# Altium schematic sheet MAIN.SchDoc
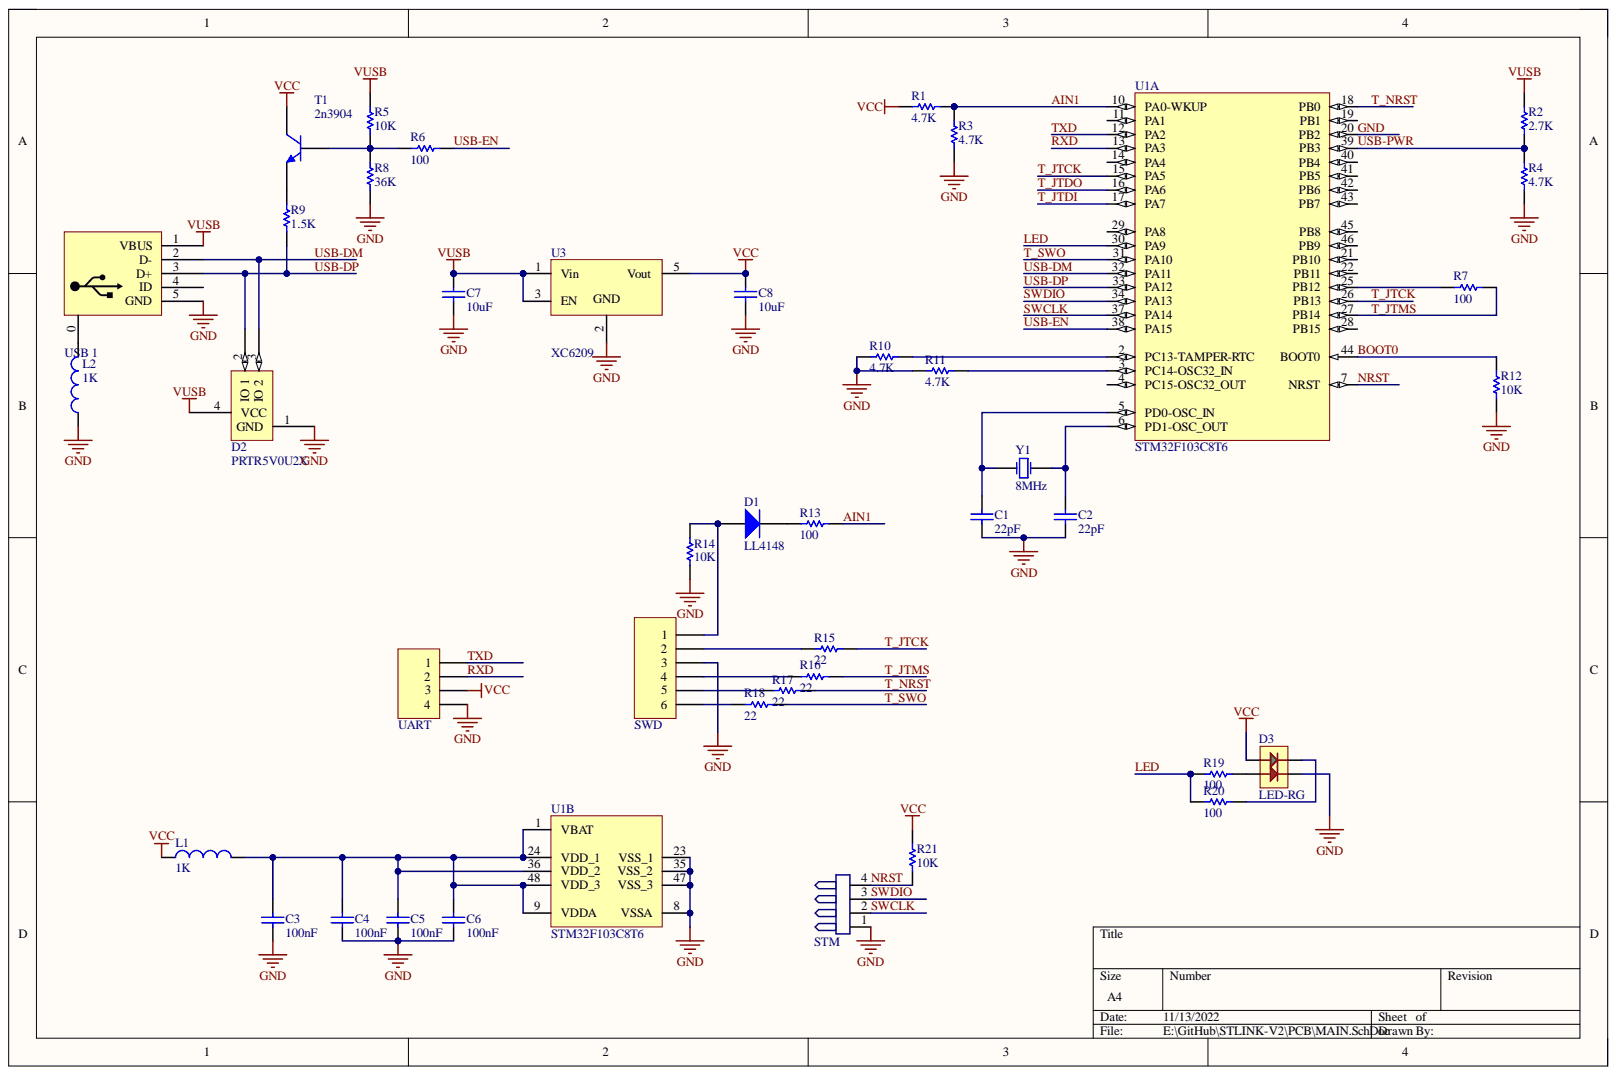
[diagram p0: MAIN.SchDoc]

## Parts
C1  22pF  [C603]
C2  22pF  [C603]
C3  100nF  [C603]
C4  100nF  [C603]
C5  100nF  [C603]
C6  100nF  [C603]
C7  10uF  [C805]
C8  10uF  [C805]
D1  LL4148  [SOD-80]
D2  PRTR5V0U2X  [SOT-143B]
D3  LED-RG  [LED-1210]
L1  1K  [r805]
L2  1K  [r805]
R1  4.7K  [R0603]
R2  2.7K  [R0603]
R3  4.7K  [R0603]
R4  4.7K  [R0603]
R5  10K  [R0603]
R6  100  [R0603]
R7  100  [R0603]
R8  36K  [R0603]
R9  1.5K  [R0603]
R10  4.7K  [R0603]
R11  4.7K  [R0603]
R12  10K  [R0603]
R13  100  [R0603]
R14  10K  [R0603]
R15  22  [R0603]
R16  22  [R0603]
R17  22  [R0603]
R18  22  [R0603]
R19  100  [R0603]
R20  100  [R0603]
R21  10K  [R0603]
STM  PHDR 4  [PH-4]  (value not drawn)
SWD  CONN-6  [XH-6]  (value not drawn)
T1  2n3904  [SOT-23-3]
U1  STM32F103C8T6  [LQFP-48]  (parts A,B)
U3  XC6209  [SOT-23-5]
UART  CONN-4  [XH-4]  (value not drawn)
USB_1  USB MINI  [USB-MINI-SMD]  (value not drawn)
Y1  8MHz  [XTAL-SMD]

## Nets
VCC: C8.2 D3.1 L1.1 R1.2 R21.2 T1.1 U3.5(Vout) UART.3
VUSB: C7.2 D2.4(VCC) R2.1 R5.1 U3.1(Vin) U3.3(EN) USB_1.1(VBUS)
AIN1: R1.1 R3.2 R13.2 U1.10(PA0-WKUP)
BOOT0: R12.2 U1.44(BOOT0)
GND: C1.1 C2.1 C3.1 C4.1 C5.1 C6.1 C7.1 C8.1 D2.1(GND) D3.3 L2.1 R3.1 R4.1 R8.2 R10.2 R11.2 R12.1 R14.2 STM.1 SWD.3 U1.8(VSSA) U1.20(PB2) U1.23(VSS_1) U1.35(VSS_2) U1.47(VSS_3) U3.2 UART.4 USB_1.5(GND)
LED: R19.2 R20.2 U1.30(PA9)
NRST: R21.1 STM.4 U1.7(NRST)
RXD: U1.13(PA3) UART.2
SWCLK: STM.2 U1.37(PA14)
SWDIO: STM.3 U1.34(PA13)
T_JTCK: R15.1 U1.15(PA5) U1.26(PB13)
T_JTDI: U1.17(PA7)
T_JTDO: U1.16(PA6)
T_JTMS: R7.1 R16.1 U1.27(PB14)
T_NRST: R17.1 U1.18(PB0)
T_SWO: R18.1 U1.31(PA10)
TXD: U1.12(PA2) UART.1
USB-DM: D2.3(IO_2) U1.32(PA11) USB_1.2(D-)
USB-DP: D2.2(IO_1) R9.2 U1.33(PA12) USB_1.3(D+)
USB-EN: R6.2 U1.38(PA15)
USB-PWR: R2.2 R4.2 U1.39(PB3)
NetC1_2: C1.2 U1.5(PD0-OSC_IN) Y1.1
NetC2_2: C2.2 U1.6(PD1-OSC_OUT) Y1.2
NetC3_2: C3.2 C4.2 C5.2 C6.2 L1.2 U1.1(VBAT) U1.9(VDDA) U1.24(VDD_1) U1.36(VDD_2) U1.48(VDD_3)
NetD1_1: D1.1 R14.1 SWD.1
NetD1_2: D1.2 R13.1
NetD3_2: D3.2 R19.1
NetD3_4: D3.4 R20.1
NetL2_2: L2.2 USB_1.0
NetR5_2: R5.2 R6.1 R8.1 T1.3
NetR7_2: R7.2 U1.25(PB12)
NetR9_1: R9.1 T1.2
NetR10_1: R10.1 U1.2(PC13-TAMPER-RTC)
NetR11_1: R11.1 U1.3(PC14-OSC32_IN)
NetR15_2: R15.2 SWD.2
NetR16_2: R16.2 SWD.4
NetR17_2: R17.2 SWD.5
NetR18_2: R18.2 SWD.6

Not connected: U1 4(PC15-OSC32_OUT) 11(PA1) 14(PA4) 19(PB1) 21(PB10) 22(PB11) 28(PB15) 29(PA8) 40(PB4) 41(PB5) 42(PB6) 43(PB7) 45(PB8) 46(PB9); USB_1 4(ID)

## Library data of the parts
C1-C8 = Cap: Capacitor; Designer: Parsa Malekpour; Component Kind: Standard; Library Name: ParsaLibrary.SchLib
  C1 C2: Value: 22pF
  C3-C6: Value: 100nF
  C7 C8: Value: 10uF
D2 = PRTR5V0U2X: Ultra low capacitance double rail-to-rail ESD protection diode; reverse standoff voltage: 5.5V; Component Kind: Standard; Library Name: ParsaLibrary.SchLib
D3 = LED-RG-4: Component Kind: Standard; Library Name: ParsaLibrary.SchLib
L1 L2 = Ferrite Bead: Code_IEC: 1005; Code_JEITA: 0402; Component Kind: Standard; Description: FERRITE BEAD; LatestRevisionDate: 29-May-2009; LatestRevisionNote: IPC-7351 Footprint Added.; PackageDescription: Chip Inductor; Published: 8-Jun-2000; Value: 1K; Library Name: ParsaLibrary.SchLib; Publisher: Altium Limited
R1-R21 = Resistor: Designer: Parsa Malekpour; Component Kind: Standard; Description: Resistor; Library Name: ParsaLibrary.SchLib
  R1 R3 R4 R10 R11: Value: 4.7K
  R2: Value: 2.7K
  R5 R12 R14 R21: Value: 10K
  R6 R7 R13 R19 R20: Value: 100
  R8: Value: 36K
  R9: Value: 1.5K
  R15-R18: Value: 22
STM = PHDR 4: PinHeader; Component Kind: Standard; Library Name: ParsaLibrary.SchLib
T1 = 2n3904: Mark: 1A; Component Kind: Standard; Library Name: ParsaLibrary.SchLib
U1 = STM32F103C8T6: ARM Cortex-M3 32-bit MCU, 64 KB Flash, 20 KB Internal RAM, 37 I/Os, 48-pin LQFP, -40 to 85 degC, Tray; A/D Converter: 2x12-bit (10 Channel); FLASH Size (Prog) (kB): 64; I/Os (High Current): 37; Internal RAM Size (kB): 20; Operating Frequency(F) (Processor speed) (MHz): 72; Supply Voltage(Vcc) min (V): 2; Component Kind: Standard; ComponentLink1Description: Manufacturer URL; ComponentLink1URL: http://www.st.com/web/en/home.html; ComponentLink2Description: Datasheet; ComponentLink2URL: http://www.st.com/st-web-ui/static/active/en/resource/technical/document/datasheet/CD00161566.pdf; ComponentLink3Description: Package Specification; ComponentLink3URL: http://www.st.com/st-web-ui/static/active/en/resource/technical/document/datasheet/DM00058181.pdf; Core: ARM Cortex-M3; DatasheetVersion: Rev. 16; Library Name: STMicroelectronics STM32 F1.IntLib; Mounting Technology: Surface Mount; PackageDescription: 48-Pin Low Profile Quad Flat Package, 7 x 7 mm Body, 0.5 mm Pitch; PackageReference: LQFP48; PackageVersion: Rev. 6, 06/2013; Packing: Tray; PartNumber: STM32F103C8T6; Supplier 1: Digi-Key; Supplier 2: Farnell; Supplier 3: Newark; Supplier 4: Newark; Supplier 5: Mouser; Supplier Part Number 1: 497-6063-ND; Supplier Part Number 2: 1447637; Supplier Part Number 3: 59M2182; Supplier Part Number 4: 57P1222; Supplier Part Number 5: 511-STM32F103C8T6
U3 = XC6209: 150 mA Low-Noise LDO Regulator; Component Kind: Standard; Library Name: ParsaLibrary.SchLib
Y1 = XTAL: Crystal Oscillator; Designer: Parsa Malekpour; Email: Malekpour.parsa@Gmail.com; Component Kind: Standard; Library Name: ParsaLibrary.SchLib; Value: 8MHz
D1 = LL4148: Component Kind: Standard; Library Name: ParsaLibrary.SchLib
SWD = CONN-6: Component Kind: Standard; Library Name: ParsaLibrary.SchLib
UART = CONN-4: Component Kind: Standard; Library Name: ParsaLibrary.SchLib
USB_1 = USB MINI: Component Kind: Standard; Library Name: ParsaLibrary.SchLib
